# Altium schematic sheet 02_Power.SchDoc
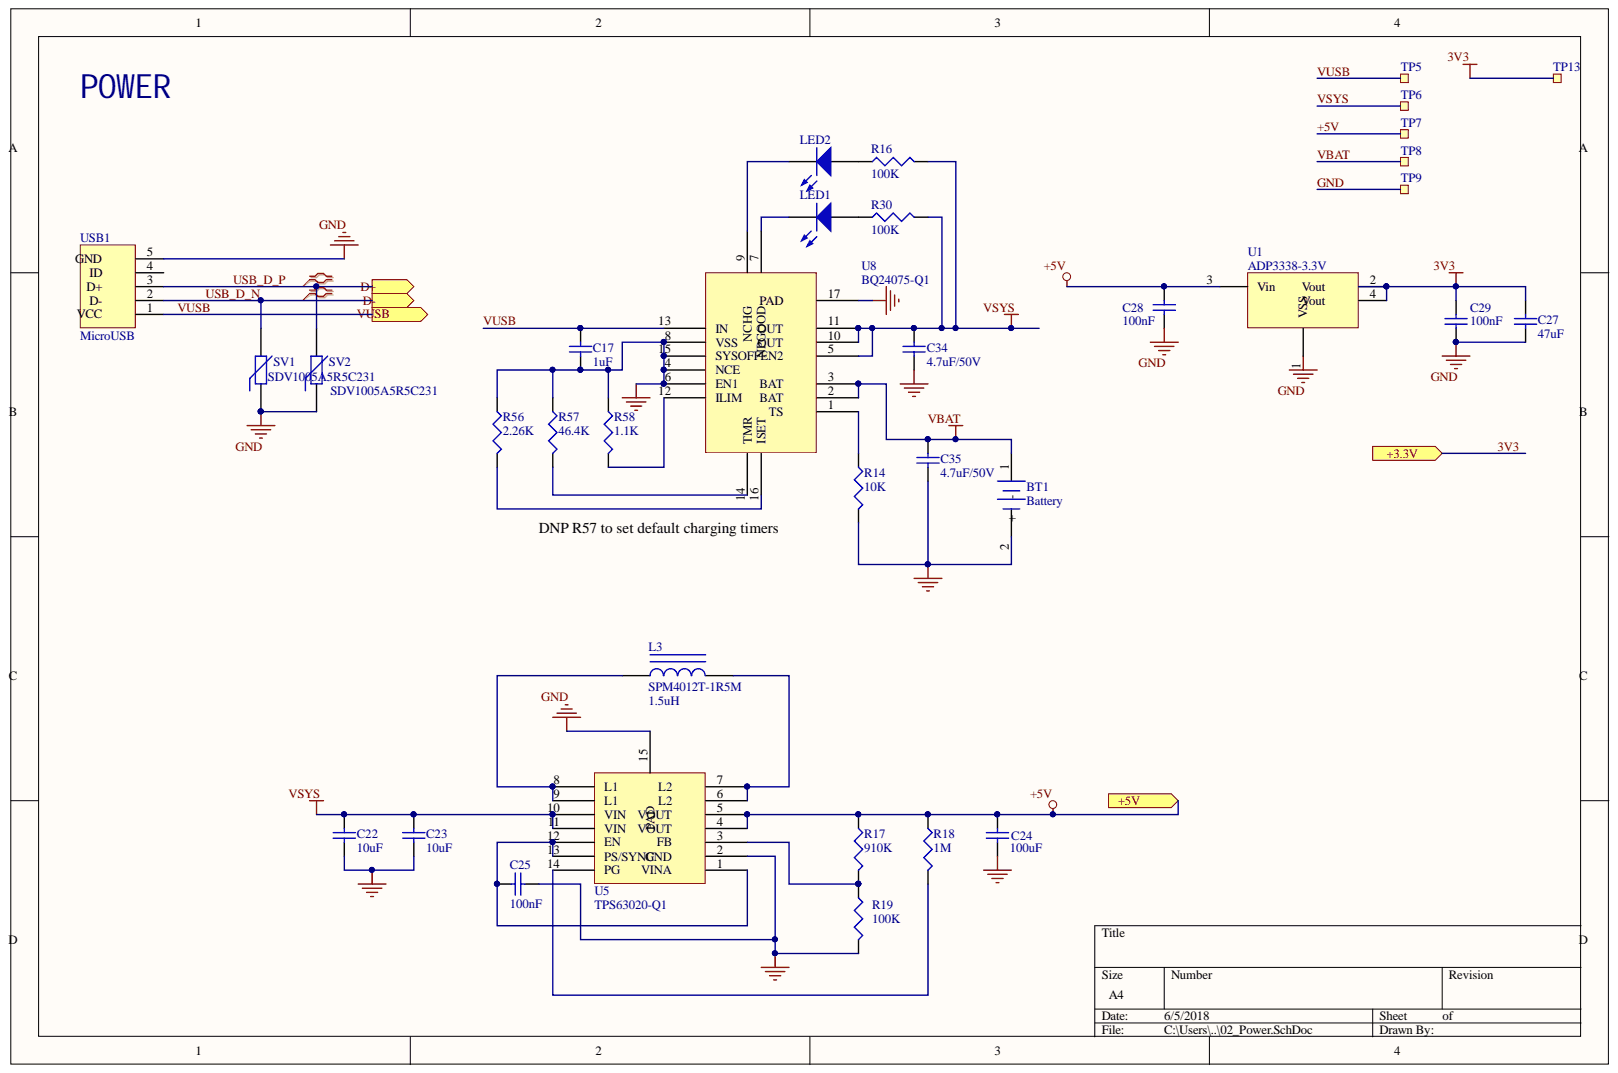
[diagram p0: 02_Power.SchDoc]

## Parts
BT1  Battery  [HDR1X2]
C17  1uF  [CAP_0603_SMD]
C22  10uF  [CAP_0603_SMD]
C23  10uF  [CAP_0603_SMD]
C24  100uF  [CAP_3216_SMD]
C25  100nF  [CAP_0603_SMD]
C27  47uF  [CAP_1206_SMD]
C28  100nF  [CAP_0603_SMD]
C29  100nF  [CAP_0603_SMD]
C34  4.7uF/50V  [0805]
C35  4.7uF/50V  [0805]
L3  SPM4012T-1R5M  value 1.5uH  [IND-4.4x4.1x1.2]
LED1  LED3  [1.6X0.8X0.8]  (value not drawn)
LED2  LED3  [1.6X0.8X0.8]  (value not drawn)
R14  10K  [RES_0603_smd]
R16  100K  [RES_0603_smd]
R17  910K  [RES_0603_SMD]
R18  1M  [RES_0603_SMD]
R19  100K  [RES_0603_SMD]
R30  100K  [RES_0603_smd]
R56  2.26K  [RES_0603_smd]
R57  46.4K  [RES_0603_smd]
R58  1.1K  [RES_0603_smd]
SV1  SDV1005A5R5C231  [RES_402_smd]
SV2  SDV1005A5R5C231  [RES_402_smd]
TP5  TP  [TP_1mm]  (no BOM)
TP6  TP  [TP_1mm]  (no BOM)
TP7  TP  [TP_1mm]  (no BOM)
TP8  TP  [TP_1mm]  (no BOM)
TP9  TP  [TP_1mm]  (no BOM)
TP13  TP  [TP_1mm]  (no BOM)
U1  ADP3338-3.3V  [SOT-223]
U5  TPS63020-Q1  [R-PVSON-N14]
U8  BQ24075-Q1  [HVSSOP-10]
USB1  MicroUSB  [MicroUSB-B_wo_Flange]

## Ports
+3.3V, +5V, D+, D-, VUSB

## Nets
3V3: C27.2 C29.2 TP13.1 U1.2 U1.4 ; port +3.3V
+5V: C24.2 C28.2 R17.1 R18.1 TP7.1 U1.3(Vin) U5.4 U5.5 ; port +5V
GND: BT1.2 C17.1 C22.2 C23.2 C24.1 C25.1 C27.1 C28.1 C29.1 C34.1 C35.1 R14.2 R19.2 R56.1 R57.1 R58.1 SV1.1 SV2.1 TP9.1 U1.1(VSS) U5.2 U5.15 U8.4(NCE) U8.6(EN1) U8.8(VSS) U8.15 U8.17 USB1.5
USB_D_N: SV1.2 USB1.2
USB_D_P: SV2.2 USB1.3
VBAT: BT1.1 C35.2 TP8.1 U8.2 U8.3
VSYS: C22.1 C23.1 C34.2 R16.2 R30.2 TP6.1 U5.10(VIN) U5.11(VIN) U8.5 U8.10 U8.11
VUSB: C17.2 TP5.1 U8.13(IN) USB1.1 ; port VUSB
NetC25_2: C25.2 U5.1 U5.12(EN) U5.13(PS/SYNC)
NetL3_1: L3.1 U5.8(L1) U5.9(L1)
NetL3_2: L3.2 U5.6 U5.7
NetLED1_1: LED1.1 R30.1
NetLED1_2: LED1.2 U8.7
NetLED2_1: LED2.1 R16.1
NetLED2_2: LED2.2 U8.9
NetR14_1: R14.1 U8.1
NetR17_2: R17.2 R19.1 U5.3
NetR18_2: R18.2 U5.14(PG)
NetR56_2: R56.2 U8.16(ISET)
NetR57_2: R57.2 U8.14(TMR)
NetR58_2: R58.2 U8.12(ILIM)

Not connected: USB1 4

## Library data of the parts
BT1 = Battery: Multicell Battery; Component Kind: Standard; LatestRevisionDate: 17-Jul-2002; LatestRevisionNote: Re-released for DXP Platform.; Library Name: Miscellaneous Devices.IntLib; PackageDescription: Battery; 2 Leads; PackageReference: BAT-2; Published: 24-Mar-1999; Publisher: Altium Limited
C17 C25 C34 C35 = Cap Semi: Capacitor (Semiconductor SIM Model); Component Kind: Standard; LatestRevisionDate: 29-May-2009; LatestRevisionNote: IPC-7351 Footprint Added.; PackageDescription: Chip Capacitor; Published: 8-Jun-2000; Signal Integrity: Cap Semi; Simulation: CAP; Library Name: C:\Users\Public\Documents\Altium\AD16\Library\Miscellaneous Devices.IntLib; Publisher: Altium Limited
  C17: PackageReference: SMD 0603; Value: 1uF
  C25: PackageReference: SMD 0805; Value: 100nF
  C34 C35: PackageReference: SMD 0805; Value: 4.7uF/50V
C22 C23 = Cap Semi: Capacitor (Semiconductor SIM Model); Manufacturer Part Number: GRM188R60J106ME84D; Component Kind: Standard; LatestRevisionDate: 29-May-2009; LatestRevisionNote: IPC-7351 Footprint Added.; PackageDescription: Chip Capacitor; PackageReference: SMD 0805; Published: 8-Jun-2000; Signal Integrity: Cap Semi; Simulation: CAP; Value: 10uF; Library Name: C:\Users\Public\Documents\Altium\AD16\Library\Miscellaneous Devices.IntLib; Publisher: Altium Limited
C24 = Cap Semi: Capacitor (Semiconductor SIM Model); Manufacturer Part Number: C3216X5R1A686M160AC; Value: 68uF; Component Kind: Standard; LatestRevisionDate: 29-May-2009; LatestRevisionNote: IPC-7351 Footprint Added.; PackageDescription: Chip Capacitor; PackageReference: SMD 0805; Published: 8-Jun-2000; Signal Integrity: Cap Semi; Simulation: CAP; Library Name: C:\Users\Public\Documents\Altium\AD16\Library\Miscellaneous Devices.IntLib; Publisher: Altium Limited
C27 = Cap Semi: Capacitor, Ceramic, 6.3V, X5R, 20%; Manufacturer Part Number: GRM31CR60J476M; Component Kind: Standard; LatestRevisionDate: 29-May-2009; LatestRevisionNote: IPC-7351 Footprint Added.; Library Name: Miscellaneous Devices.IntLib; PackageDescription: Chip Capacitor; PackageReference: C1206; Published: 8-Jun-2000; Signal Integrity: Cap Semi; Simulation: CAP; Value: 47uF; Publisher: Altium Limited
C28 C29 = Cap: Capacitor; Manufacturer Part Number: GCM188R71C104KA37D; Component Kind: Standard; LatestRevisionDate: 17-Jul-2002; LatestRevisionNote: Re-released for DXP Platform.; Library Name: Miscellaneous Devices.IntLib; PackageDescription: Radial Cap, Thru-Hole; 2 Leads; 0.3 in Pin Spacing; PackageReference: SMD 0603; Published: 8-Jun-2000; Signal Integrity: Cap; Simulation: CAP; Value: 100nF; Publisher: Altium Limited
L3 = Inductor Iron: Magnetic-Core Inductor; Component Kind: Standard; LatestRevisionDate: 17-Jul-2002; LatestRevisionNote: Re-released for DXP Platform.; Library Name: Miscellaneous Devices.IntLib; Manufacturer Part Number: SPM4012T-1R5M; PackageDescription: Axial Device, Thru-Hole; 2 Leads; 0.9 in Pin Spacing; PackageReference: AXIAL-0.9; Published: 24-Mar-1999; Signal Integrity: Inductor Iron; Simulation: INDUCTOR; Publisher: Altium Limited
LED1 LED2 = LED3: Typical BLUE SiC LED; Component Kind: Standard; DatasheetVersion: 16-Apr-2002; LatestRevisionDate: 17-Dec-2003; LatestRevisionNote: PCB Footprint replaced.; PackageDescription: SM LED; 2 C-Bend Leads; Body 3.5 x 2.8 x 1.9 mm, inc leads (LxWxH typ); PackageReference: SMD 0603; PackageVersion: 16-Apr-2002; Published: 8-Jun-2000; Signal Integrity: LED; Simulation: LED3; Library Name: C:\Users\Public\Documents\Altium\AD16\Library\Miscellaneous Devices.IntLib; Publisher: Altium Limited
R14 R17-R19 R56-R58 = Res3: Resistor; Component Kind: Standard; LatestRevisionDate: 29-May-2009; LatestRevisionNote: IPC-7351 Footprint Added.; PackageDescription: Chip Resistor; Published: 8-Jun-2000; Signal Integrity: Res; Simulation: RESISTOR; Library Name: C:\Users\Public\Documents\Altium\AD16\Library\Miscellaneous Devices.IntLib; Publisher: Altium Limited
  R14: PackageReference: SMD 0402; Value: 10K
  R17: PackageReference: SMD 0805; Value: 910K
  R18: PackageReference: SMD 0805; Value: 1M
  R19: PackageReference: SMD 0805; Value: 100K
  R56: PackageReference: SMD 0402; Value: 2.26K
  R57: PackageReference: SMD 0402; Value: 46.4K
  R58: PackageReference: SMD 0402; Value: 1.1K
R16 R30 = Res3: Resistor; Value: 240; Component Kind: Standard; LatestRevisionDate: 29-May-2009; LatestRevisionNote: IPC-7351 Footprint Added.; PackageDescription: Chip Resistor; PackageReference: SMD 0603; Published: 8-Jun-2000; Signal Integrity: Res; Simulation: RESISTOR; Library Name: C:\Users\Public\Documents\Altium\AD16\Library\Miscellaneous Devices.IntLib; Publisher: Altium Limited
SV1 SV2 = Res Varistor: Varistor (Voltage-Sensitive Resistor); Component Kind: Standard; LatestRevisionDate: 04-Mar-2005; LatestRevisionNote: PCB Footprint replaced.; Library Name: Miscellaneous Devices.IntLib; PackageReference: 6-0805; Published: 24-Mar-1999; Publisher: Altium Limited
TP5-TP9 TP13 = Testpoint TH: Testpoint, through hole; Artikkelnr Noca: N.A.; Artikkelnr Simpro: N.A.; Footprint Doc: TESTPAD-TH; LatestRevisionDate: 2009-12-12; Library Name: pca10056.IntLib; Part Number: Testpoint TH; Pin Count: 1; Published: 2009-12-12; Value: TP; Publisher: Nordic Semiconductor
U1 = NCP1117: Voltage Regulator_LDO; Component Kind: Standard; Manufacturer Part Number: ADP3338-3.3V
U5 = TPS63020-Q1: High Efficiency Single Inductor Buck-Boost Converter With 4-A Switches; Component Kind: Standard; Library Name: ATmega2560_Library.SchLib; Manufacturer Part Number: TPS63020-Q1
U8 = BQ24075-Q1: Li-Ion BATTERY CHARGER AND POWER-PATH MANAGEMENT IC; Component Kind: Standard; Library Name: OBD_LINK_Schlib.SchLib
USB1 = MicroUSB: Component Kind: Standard; Library Name: ZYF_Connector.SchLib
